# Altium schematic sheet Esquematico.SchDoc(Esquematico)
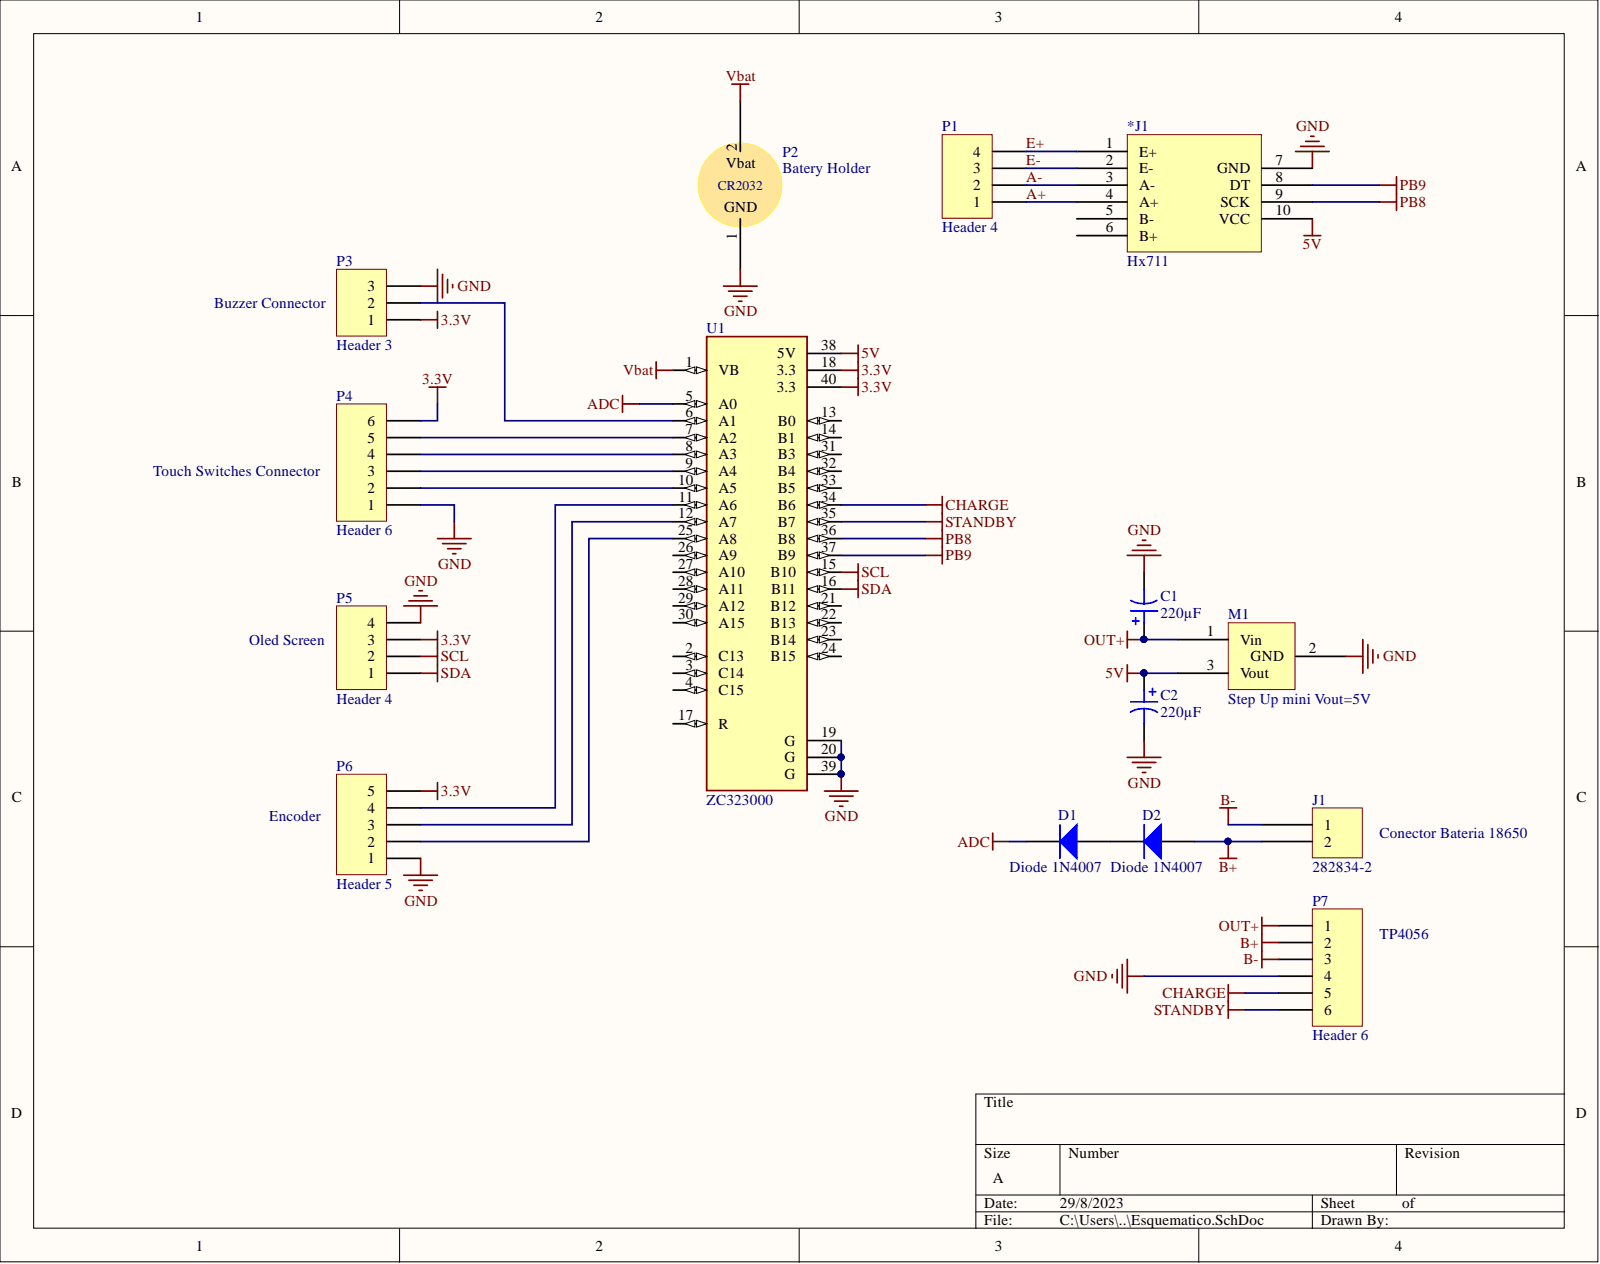
[diagram p0: Esquematico.SchDoc]

## Parts
*J1  Hx711  [HX711]
C1  220µF  [CAP TH ALUM ELEC D10.00mm S5.00mm H12.50mm]
C2  220µF  [CAP TH ALUM ELEC D10.00mm S5.00mm H12.50mm]
D1  Diode 1N4007  [DO-41]
D2  Diode 1N4007  [DO-41]
J1  282834-2  [TE 282834-2]
M1  Step Up mini Vout=5V  [StepUp Mini 5V]
P1  Header 4  [HDR1X4]
P2  Batery Holder  [20mm Cell Holder]
P3  Header 3  [HDR1X3]
P4  Header 6  [HDR1X6]
P5  Header 4  [HDR1X4]
P6  Header 5  [HDR1X5]
P7  Header 6  [HDR1X6]
U1  ZC323000  [MODULE_ZC323000]

## Nets
3.3V: P3.1 P4.6 P5.3 P6.5 U1.18(3.3) U1.40(3.3)
5V: *J1.10(VCC) C2.1 M1.3 U1.38(5V)
ADC: D1.2 U1.5(A0)
B+: D2.1 J1.2 P7.2
B-: J1.1 P7.3
CHARGE: P7.5 U1.34(B6)
GND: *J1.7(GND) C1.2 C2.2 M1.2 P2.1 P3.3 P4.1 P5.4 P6.1 P7.4 U1.19(G) U1.20(G) U1.39(G)
OUT+: C1.1 M1.1 P7.1
PB8: *J1.9(SCK) U1.36(B8)
PB9: *J1.8(DT) U1.37(B9)
SCL: P5.2 U1.15(B10)
SDA: P5.1 U1.16(B11)
STANDBY: P7.6 U1.35(B7)
Vbat: P2.2 U1.1(VB)
A+: *J1.4(A+) P1.1
A-: *J1.3(A-) P1.2
E+: *J1.1(E+) P1.4
E-: *J1.2(E-) P1.3
NetD1_1: D1.1 D2.2
NetP3_2: P3.2 U1.6(A1)
NetP4_2: P4.2 U1.10(A5)
NetP4_3: P4.3 U1.9(A4)
NetP4_4: P4.4 U1.8(A3)
NetP4_5: P4.5 U1.7(A2)
NetP6_2: P6.2 U1.25(A8)
NetP6_3: P6.3 U1.12(A7)
NetP6_4: P6.4 U1.11(A6)

Not connected: *J1 5(B-) 6(B+); U1 2(C13) 3(C14) 4(C15) 13(B0) 14(B1) 17(R) 21(B12) 22(B13) 23(B14) 24(B15) 26(A9) 27(A10) 28(A11) 29(A12) 30(A15) 31(B3) 32(B4) 33(B5)

## Library data of the parts
C1 C2 = UVZ1H221MPD: CAP ALUM 220UF 20% 50V RADIAL; manufacturer: Nichicon; Ripple Current @ Low Frequency: 300mA @ 120Hz; Ripple Current @ High Frequency: 450mA @ 10kHz; Polarization: Polar; Lifetime @ Temp.: 1000 Hrs @ 105°C; Database Table Name: Capacitors - Aluminum - Electrolytic TH; Voltage - Rated: 50V; value: 220µF; Tolerance: ±20%; Supplier Part Number 1: 493-1342-ND; Supplier 1: DigiKey; Size / Dimension: 0.394" Dia (10.00mm); series: UVZ; price: 0.41; Part Status: Active; Part Number: UVZ1H221MPD; partid: 10709; packaging: Bulk; Package / Case: Radial, Can; Operating Temperature: -55°C ~ 105°C; Mounting Type: Through Hole; Minimum Order: 1; Lifecycle Status: Active; Library Name: Celestial Altium Library - altium_library.DbLib; Lead Spacing: 0.197" (5.00mm); lastupdated: 2/9/2020 01:31:04; Height - Seated (Max): 0.492" (12.50mm); Device Package: Radial, Can; componentlink2url: http://digikey.com/product-detail/en/nichicon/UVZ1H221MPD/493-1342-ND/589083; componentlink2description: DigiKey Link; componentlink1url: http://nichicon-us.com/english/products/pdfs/e-uvz.pdf; componentlink1description: Datasheet; Component Kind: Standard; Capacitance: 220µF; Applications: General Purpose
D1 D2 = Diode 1N4007: 1 Amp General Purpose Rectifier; Simulation: 1N4007; Signal Integrity: 1N4007; Published: 8-Jun-2000; PackageVersion: Aug-1999; PackageReference: DO-41; PackageDescription: Diode, Axial; Body 5.2 x 2.7 mm (LxDia. max), Lead Dia. 0.86 mm (max); Library Name: Miscellaneous Devices.IntLib; LatestRevisionNote: Re-released for DXP Platform.; LatestRevisionDate: 17-Jul-2002; DatasheetVersion: 1998; ComponentLink2URL: http://www.fairchildsemi.com/ds/1N%2F1N4001.pdf; ComponentLink2Description: Datasheet; ComponentLink1URL: http://www.fairchildsemi.com/; ComponentLink1Description: Manufacturer Link; Component Kind: Standard; Code_JEDEC: DO-204-AL; Publisher: Altium Limited
J1 = 282834-2: TERM BLK 2P SIDE ENT 2.54MM PCB; Manufacturer: TE Connectivity AMP Connectors; Wire Termination: Screw - Rising Cage Clamp; Wire Gauge: 16-30 AWG; Voltage: 150V; Positions Per Level: 2; Pitch: 0.100" (2.54mm); Number of Levels: 1; Mating Orientation: Horizontal with Board; Database Table Name: Connectors - Terminal Blocks - Wire to Board; Current: 10A; Supplier Part Number 1: A98333-ND; Supplier 1: DigiKey; Series: Buchanan; Price: 0.88; Part Status: Active; Part Number: 282834-2; Packaging: Bulk; Mounting Type: Through Hole; Minimum Order: 1; Lifecycle Status: Active; Library Name: Celestial Altium Library - altium_library.DbLib; LastUpdated: 15/1/2020 04:15:38; ComponentLink2URL: http://digikey.com/product-detail/en/te-connectivity-amp-connectors/282834-2/A98333-ND/1150135; ComponentLink2Description: DigiKey Link; ComponentLink1URL: https://www.te.com/commerce/DocumentDelivery/DDEController?Action=srchrtrv&DocNm=282834&DocType=Customer+Drawing&DocLang=English; ComponentLink1Description: Datasheet; Component Kind: Standard; Color: Green
M1 = StepUp 5V: Component Kind: Standard; Library Name: BateryHolder.SchLib
P1 P5 = Header 4: Header, 4-Pin; Signal Integrity: Connector; Library Name: Miscellaneous Connectors.IntLib; LatestRevisionNote: Re-released for DXP Platform.; LatestRevisionDate: 17-Jul-2002; Component Kind: Standard; Publisher: Altium Limited
P2 = Component_1: Library Name: BateryHolder.SchLib; Component Kind: Standard
P3 = Header 3: Header, 3-Pin; Signal Integrity: Connector; Library Name: Miscellaneous Connectors.IntLib; LatestRevisionNote: Re-released for DXP Platform.; LatestRevisionDate: 17-Jul-2002; Component Kind: Standard; Publisher: Altium Limited
P4 P7 = Header 6: Header, 6-Pin; Signal Integrity: Connector; Library Name: Miscellaneous Connectors.IntLib; LatestRevisionNote: Re-released for DXP Platform.; LatestRevisionDate: 17-Jul-2002; Component Kind: Standard; Publisher: Altium Limited
P6 = Header 5: Header, 5-Pin; Signal Integrity: Connector; Library Name: Miscellaneous Connectors.IntLib; LatestRevisionNote: Re-released for DXP Platform.; LatestRevisionDate: 17-Jul-2002; Component Kind: Standard; Publisher: Altium Limited
U1 = ZC323000: STM32F103C8T6 ARM STM32 Minimum System Development Board Module For Arduino; Price: None; Package: None; MP: ZC323000; Library Name: ZC323000.IntLib; Component Kind: Standard; Availability: Unavailable
*J1 = Hx711: Library Name: modulos.IntLib; Component Kind: Standard
